# Altium schematic sheet WIRELESS.SchDoc
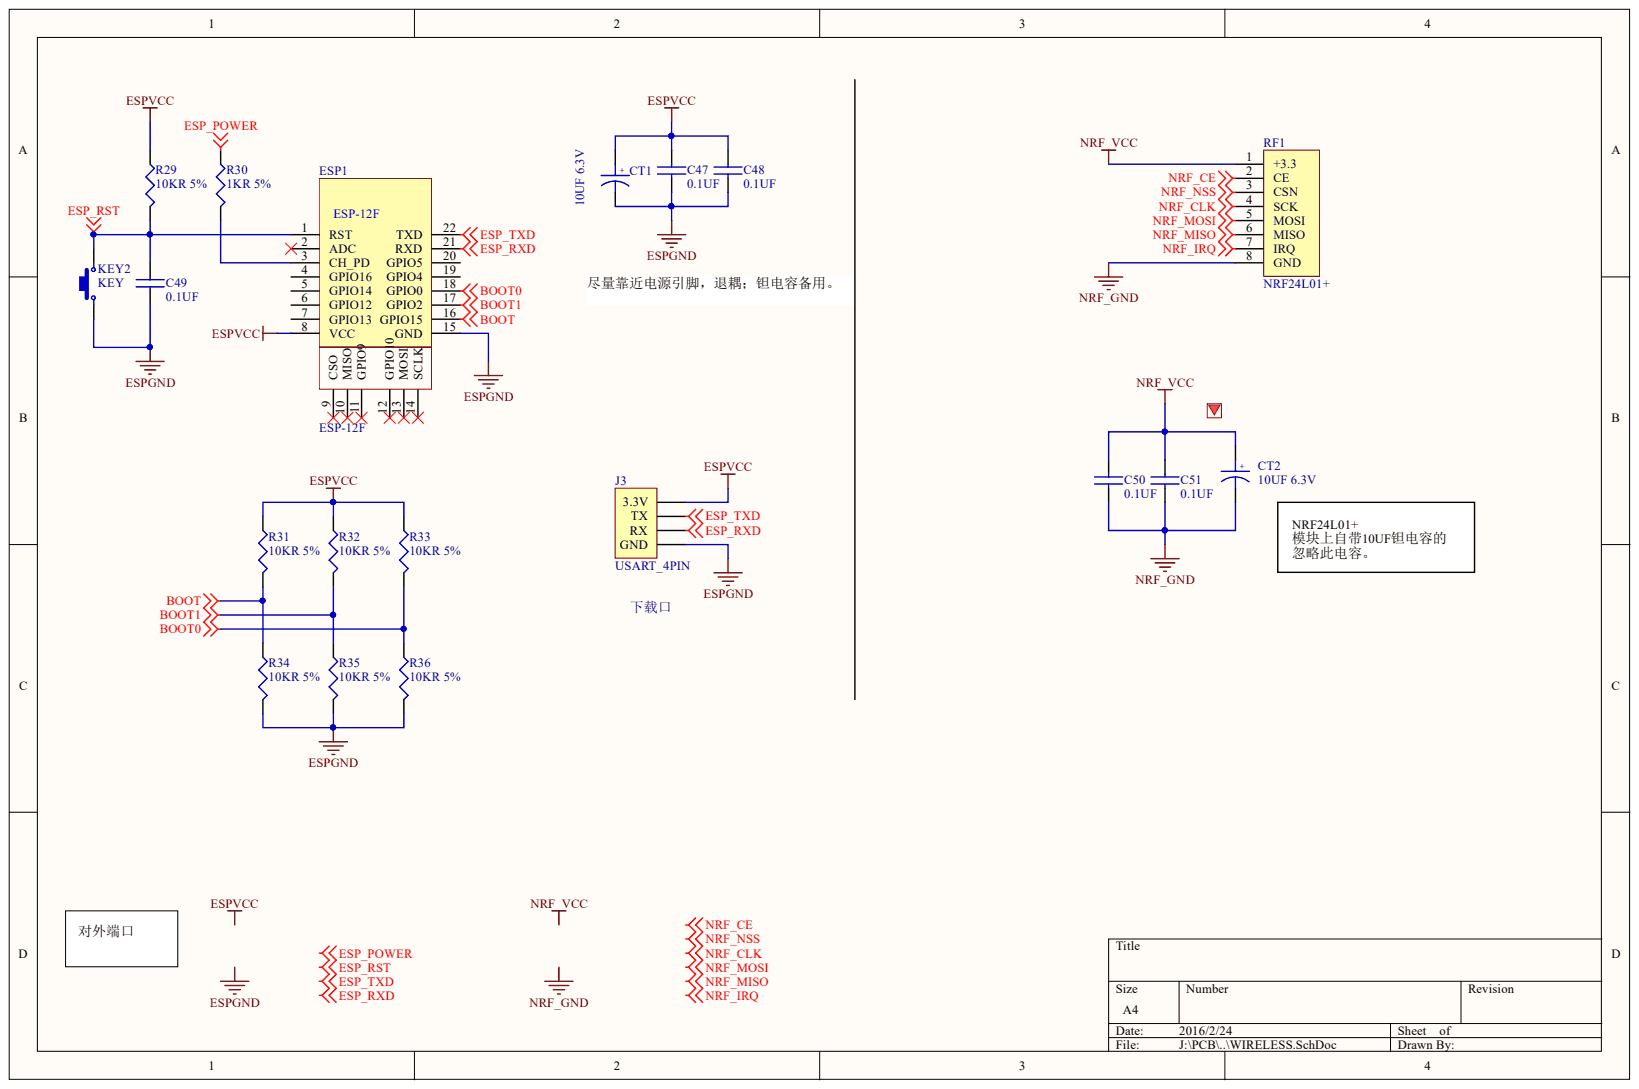
[diagram p0: WIRELESS.SchDoc]

## Parts
C47  0.1UF  [CAPC1005X05N]
C48  0.1UF  [CAPC1005X05N]
C49  0.1UF  [CAPC1005X05N]
C50  0.1UF  [CAPC1005X05N]
C51  0.1UF  [CAPC1005X05N]
CT1  10UF 6.3V  [CAPMP3216X180]
CT2  10UF 6.3V  [CAPMP3216X180]
ESP1  ESP-12F  [ESP-12F]
J3  USART_4PIN  [Header 4X1]
KEY2  KEY  [KEY_DBLPin_3X6-SMT]
R29  10KR 5%  [RESC1005X04N]
R30  1KR 5%  [RESC1005X04N]
R31  10KR 5%  [RESC1005X04N]
R32  10KR 5%  [RESC1005X04N]
R33  10KR 5%  [RESC1005X04N]
R34  10KR 5%  [RESC1005X04N]
R35  10KR 5%  [RESC1005X04N]
R36  10KR 5%  [RESC1005X04N]
RF1  NRF24L01+  [NRF24L01 YJ PA SMD]

## Nets
BOOT: ESP1.16(GPIO15) R31.2 R34.1
BOOT0: ESP1.18(GPIO0) R33.2 R36.1
BOOT1: ESP1.17(GPIO2) R32.2 R35.1
ESP_POWER: R30.1
ESP_RST: C49.1 ESP1.1(RST) KEY2.2 R29.2
ESP_RXD: ESP1.21(RXD) J3.3(RX)
ESP_TXD: ESP1.22(TXD) J3.2(TX)
ESPGND: C47.2 C48.2 C49.2 CT1.2 ESP1.15(GND) J3.4(GND) KEY2.1 R34.2 R35.2 R36.2
ESPVCC: C47.1 C48.1 CT1.1 ESP1.8(VCC) J3.1(3.3V) R29.1 R31.1 R32.1 R33.1
NRF_CE: RF1.2(CE)
NRF_CLK: RF1.4(SCK)
NRF_GND: C50.2 C51.2 CT2.2 RF1.8(GND)
NRF_IRQ: RF1.7(IRQ)
NRF_MISO: RF1.6(MISO)
NRF_MOSI: RF1.5(MOSI)
NRF_NSS: RF1.3(CSN)
NRF_VCC: C50.1 C51.1 CT2.1 RF1.1(+3.3)
NetESP1_3: ESP1.3(CH_PD) R30.2

Not connected: ESP1 2(ADC) 4(GPIO16) 5(GPIO14) 6(GPIO12) 7(GPIO13) 9(CSO) 10(MISO) 11(GPIO9) 12(GPIO10) 13(MOSI) 14(SCLK) 19(GPIO4) 20(GPIO5)

## Library data of the parts
C47-C51 = CAP: Capacitor nonpolarity; Component Kind: Standard; Library Name: RCL_Standard.SchLib
CT1 CT2 = CAPACITOR POL: Capacitor polarity; Component Kind: Standard; Library Name: RCL_Standard.SchLib
ESP1 = ESP-12F AI: 安信可ESP-12F,ESP8266,WIFI; Component Kind: Standard; Library Name: Wireless.SchLib
J3 = USART_4PIN: 3.3/T/R/G; Component Kind: Standard; Library Name: Connector.SchLib
KEY2 = KEY: 两脚微动开关; Component Kind: Standard; Library Name: Other.SchLib
R29-R36 = R: General Resistors; Component Kind: Standard; Library Name: RCL_Standard.SchLib
RF1 = NRF24L01 YJ SMD: YJ SMD,Wirless modular; Component Kind: Standard; Library Name: Wireless.SchLib
